# Altium schematic sheet POWER_MISC.SchDoc(POWER_MISC)
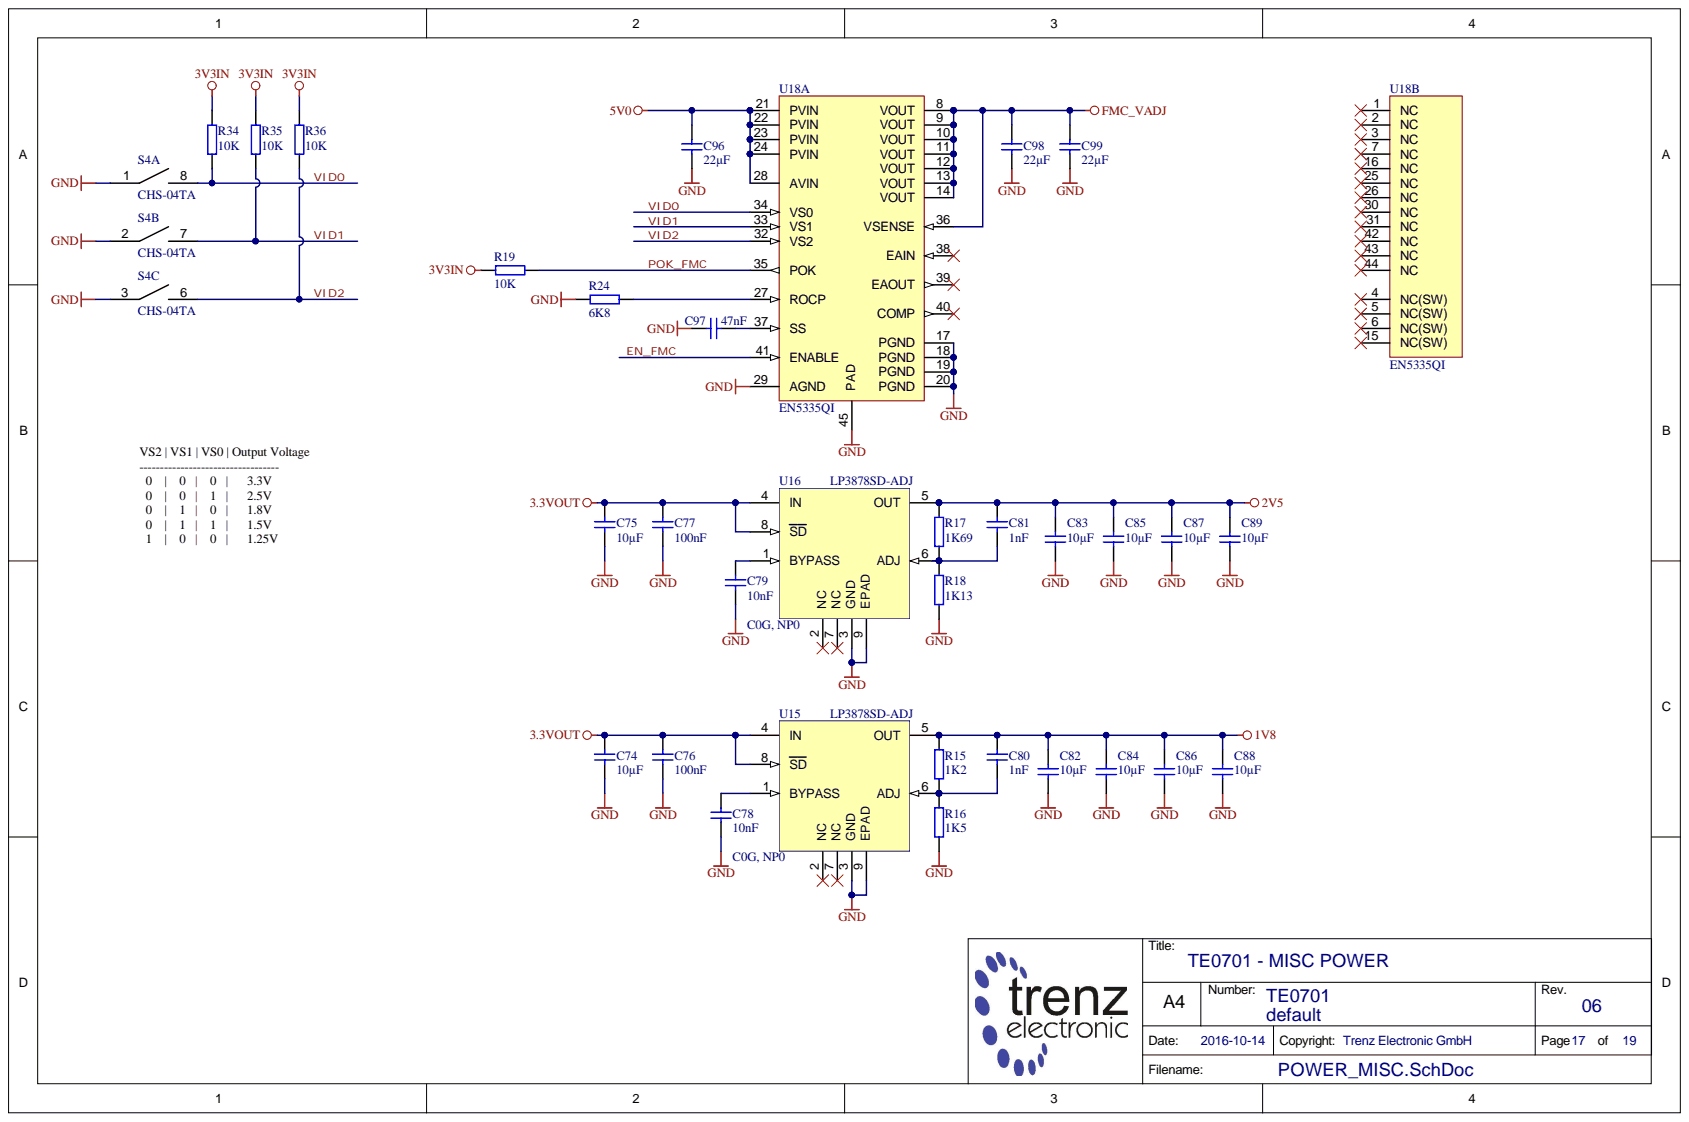
[diagram p0: POWER_MISC.SchDoc]

## Parts
C74  10µF  [CAPC2012X135N]
C75  10µF  [CAPC2012X135N]
C76  100nF  [CAPC1005X55N]
C77  100nF  [CAPC1005X55N]
C78  10nF  [CAPC1608X90N]
C79  10nF  [CAPC1608X90N]
C80  1nF  [CAPC1005X55N]
C81  1nF  [CAPC1005X55N]
C82  10µF  [CAPC2012X135N]
C83  10µF  [CAPC2012X135N]
C84  10µF  [CAPC2012X135N]
C85  10µF  [CAPC2012X135N]
C86  10µF  [CAPC2012X135N]
C87  10µF  [CAPC2012X135N]
C88  10µF  [CAPC2012X135N]
C89  10µF  [CAPC2012X135N]
C96  22µF  [CAPC3216X180N]
C97  47nF  [CAPC1005X55N]
C98  22µF  [CAPC3216X180N]
C99  22µF  [CAPC3216X180N]
R15  1K2  [RESC1005X40N]
R16  1K5  [RESC1005X40N]
R17  1K69  [RESC1005X40N]
R18  1K13  [RESC1005X40N]
R19  10K  [RESC1005X40N]
R24  6K8  [RESC1005X40N]
R34  10K  [RESC1005X40N]
R35  10K  [RESC1005X40N]
R36  10K  [RESC1005X40N]
S4  CHS-04TA  [CHS-04TA]  (parts A,B,C)
U15  LP3878SD-ADJ  [SON80P400X400X80-9N]
U16  LP3878SD-ADJ  [SON80P400X400X80-9N]
U18  EN5335QI  [EN5335QI]  (parts A,B)

## Nets
1V8: C80.1 C82.1 C84.1 C86.1 C88.1 R15.1 U15.5(OUT)
2V5: C81.1 C83.1 C85.1 C87.1 C89.1 R17.1 U16.5(OUT)
3.3VOUT: C74.1 C75.1 C76.1 C77.1 U15.4(IN) U15.8(SD) U16.4(IN) U16.8(SD)
3V3IN: R19.1 R34.2 R35.2 R36.2
5V0: C96.1 U18.21(PVIN) U18.22(PVIN) U18.23(PVIN) U18.24(PVIN) U18.28(AVIN)
FMC_VADJ: C98.1 C99.1 U18.8(VOUT) U18.9(VOUT) U18.10(VOUT) U18.11(VOUT) U18.12(VOUT) U18.13(VOUT) U18.14(VOUT) U18.36(VSENSE)
GND: C74.2 C75.2 C76.2 C77.2 C78.2 C79.2 C82.2 C83.2 C84.2 C85.2 C86.2 C87.2 C88.2 C89.2 C96.2 C97.1 C98.2 C99.2 R16.2 R18.2 R24.1 S4.1 S4.2 S4.3 U15.3(GND) U15.9(EPAD) U16.3(GND) U16.9(EPAD) U18.17(PGND) U18.18(PGND) U18.19(PGND) U18.20(PGND) U18.29(AGND) U18.45(PAD)
EN_FMC: U18.41(ENABLE)
POK_FMC: R19.2 U18.35(POK)
VID0: R34.1 S4.8 U18.34(VS0)
VID1: R35.1 S4.7 U18.33(VS1)
VID2: R36.1 S4.6 U18.32(VS2)
NetC78_1: C78.1 U15.1(BYPASS)
NetC79_1: C79.1 U16.1(BYPASS)
NetC80_2: C80.2 R15.2 R16.1 U15.6(ADJ)
NetC81_2: C81.2 R17.2 R18.1 U16.6(ADJ)
NetC97_2: C97.2 U18.37(SS)
NetR24_2: R24.2 U18.27(ROCP)

Not connected: U15 2(NC) 7(NC); U16 2(NC) 7(NC); U18 1(NC) 2(NC) 3(NC) 4(NC(SW)) 5(NC(SW)) 6(NC(SW)) 7(NC) 15(NC(SW)) 16(NC) 25(NC) 26(NC) 30(NC) 31(NC) 38(EAIN) 39(EAOUT) 40(COMP) 42(NC) 43(NC) 44(NC)

## Library data of the parts
C74 C75 C82-C89 = 24380: KON 10µF 16V X7R SMD 10%; Database Table Name: C_2012_0805; Mixed: SMT; Preferred: Yes; Value: 10u; AN: 24380; Case: 2012 (0805); Component Kind: Standard; Library Name: TE.DbLib; Technology: X7R; Tolerance: 10%; Voltage: 16V
C76 C77 = 22472: KON 100nF 25V X5R SMD; Database Table Name: C_1005_0402; Mixed: SMT; Preferred: Yes; Value: 100n; AN: 22472; Case: 1005 (0402); Component Kind: Standard; Library Name: TE.DbLib; Technology: X7R; Voltage: 25V
C78 C79 = 23662: KON 10nF 50V C0G, NP0 SMD; Database Table Name: C_1608_0603; Mixed: SMT; Value: 10n; Voltage: 16V; AN: 23662; Case: 1608 (0603); Component Kind: Standard; Library Name: TE.DbLib; Technology: C0G, NP0
C80 C81 = 22471: KON 1nF 50V X7R SMD; Database Table Name: C_1005_0402; Mixed: SMT; Preferred: Yes; Tolerance: 10%; Value: 1n; AN: 22471; Case: 1005 (0402); Component Kind: Standard; Library Name: TE.DbLib; Technology: X7R; Voltage: 50V
C96 C98 C99 = 24886: KON 22µF X5R 25V SMD 10%; Database Table Name: C_3216_1206; Mixed: SMT; Preferred: Yes; Value: 22u; AN: 24886; Case: 3216 (1206); Component Kind: Standard; Library Name: TE.DbLib; Tolerance: 10%; Voltage: 25V
C97 = 24684: KON 47nF 50V X7R SMD 10%; Database Table Name: C_1005_0402; Mixed: SMT; Value: 47n; AN: 24684; Case: 1005 (0402); Component Kind: Standard; Library Name: TE.DbLib; Technology: X7R; Tolerance: 10%; Voltage: 50V
R15 = 24132: WID SMD 1K2 1% 0,063W; Database Table Name: R_1005_0402; Mixed: SMT; Value: 1.2K; AN: 24132; Case: 1005 (0402); Component Kind: Standard; Library Name: TE.DbLib; Technology: TK100; Tolerance: 1%
R16 = 22602: WID SMD 1K5 1% 0,063W; Database Table Name: R_1005_0402; Mixed: SMT; Preferred: Yes; Value: 1.5K; AN: 22602; Case: 1005 (0402); Component Kind: Standard; Library Name: TE.DbLib; Technology: TK100; Tolerance: 1%
R17 = 23407: WID SMD 1K69 1% 0,063W; Database Table Name: R_1005_0402; Mixed: SMT; Value: 1.69K; AN: 23407; Case: 1005 (0402); Component Kind: Standard; Library Name: TE.DbLib; Technology: TK100; Tolerance: 1%
R18 = 23160: WID SMD 1K13 1% 0,063W; Database Table Name: R_1005_0402; Mixed: SMT; Preferred: Yes; Value: 1.13K; AN: 23160; Case: 1005 (0402); Component Kind: Standard; Library Name: TE.DbLib; Technology: TK100; Tolerance: 1%
R19 R34-R36 = 22750: WID SMD 10K 1% 0,063W; Database Table Name: R_1005_0402; Mixed: SMT; Preferred: Yes; AN: 22750; Case: 1005 (0402); Component Kind: Standard; Library Name: TE.DbLib; Technology: TK100; Tolerance: 1%; Value: 10K
R24 = 22601: WID SMD 6K8 1% 0,063W; Database Table Name: R_1005_0402; Mixed: SMT; Preferred: Yes; Value: 6.8K; AN: 22601; Case: 1005 (0402); Component Kind: Standard; Library Name: TE.DbLib; Technology: TK100; Tolerance: 1%
S4 = 23417: DIP Schalter 4 fach 1,27mm SMD; Database Table Name: PB_SW; Mixed: SMT; Preferred: Yes; AN: 23417; Component Kind: Standard; H-ART: CHS-04TA; Library Name: TE.DbLib; Trenz-Stock: Yes; HelpURL: B:\AD\adlib\datasheet\CHS
U15 U16 = 23663: LP3878SD-ADJ/NOPB 800mA; Database Table Name: LREG; Mixed: SMT; Preferred: Yes; AN: 23663; Case: LLP; Component Kind: Standard; H-ART: LP3878SD-ADJ/NOPB; Library Name: TE.DbLib; Trenz-Stock: Yes; HelpURL: B:\AD\adlib\datasheet\LP3878xxx-ADJ
U18 = 25127: Database Table Name: DCDC; Mixed: SMT; Preferred: Yes; AN: 25127; Component Kind: Standard; Description: EN5335QI; H-ART: EN5335QI; Library Name: TE.DbLib; Trenz-Stock: Yes; HelpURL: B:\AD\adlib\datasheet\EN5335QI
